# Altium schematic sheet 基本外设.SchDoc
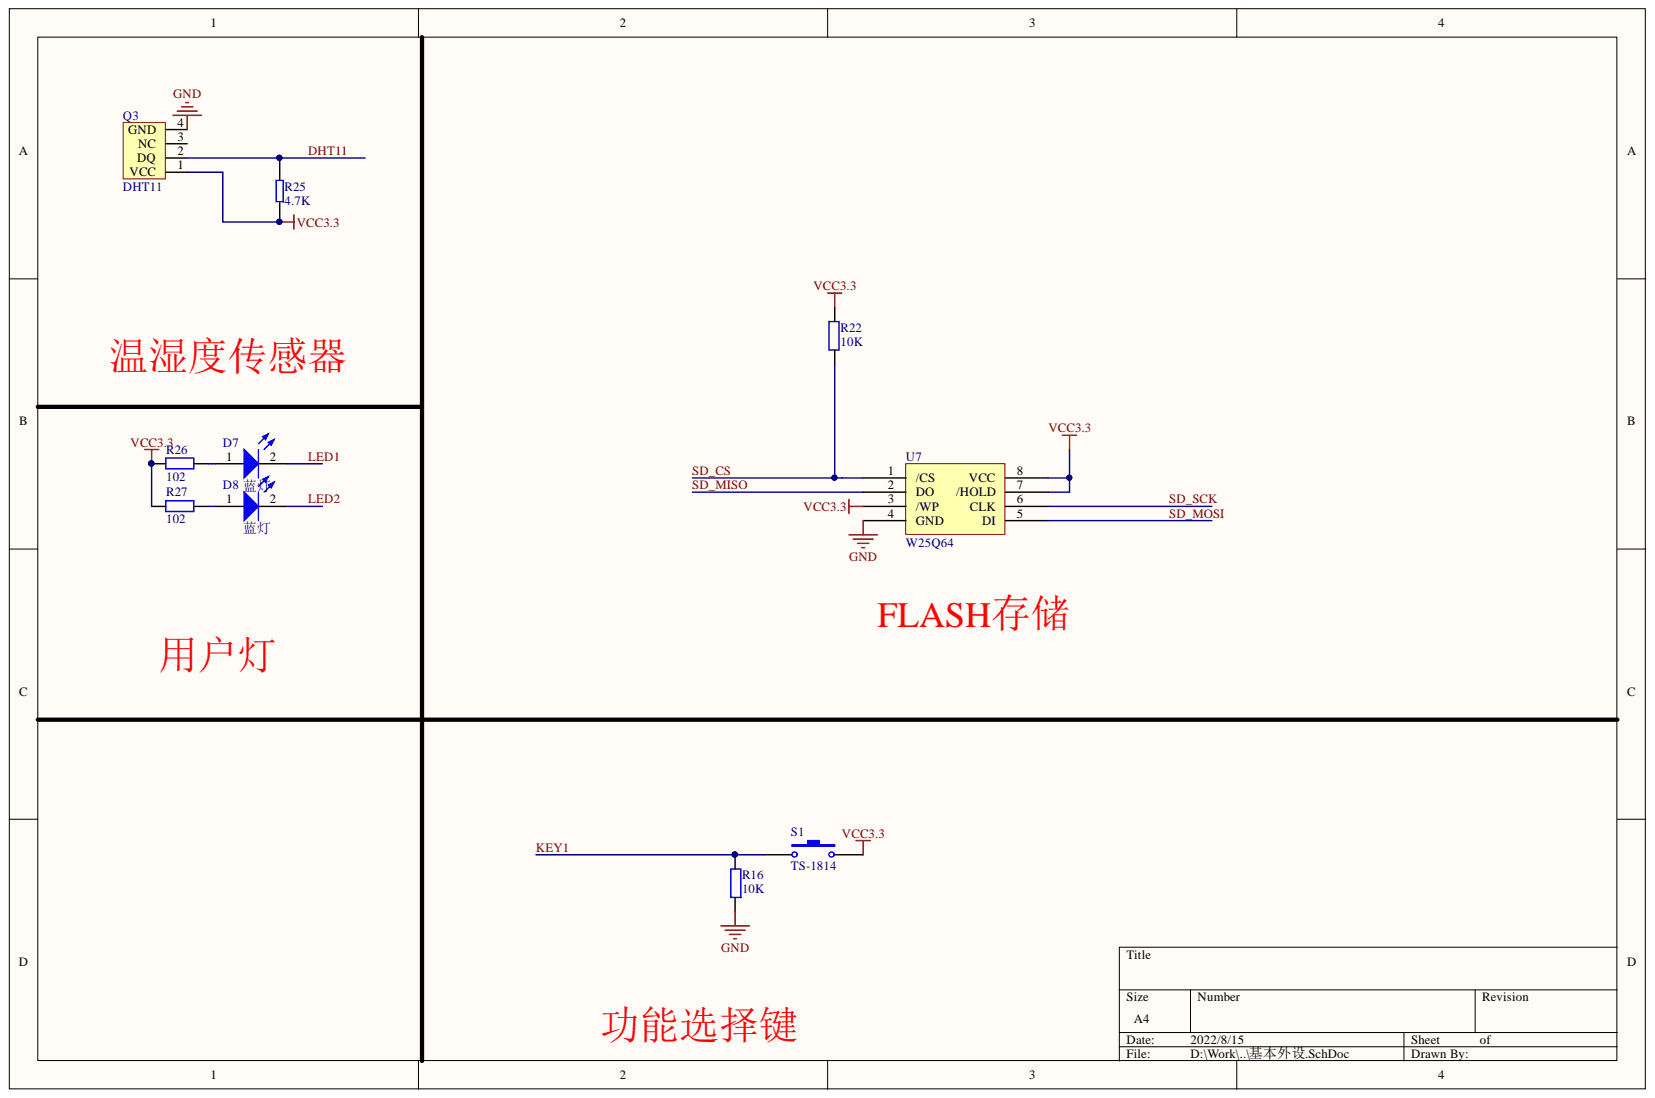
[diagram p0: 基本外设.SchDoc]

## Parts
D7  蓝灯  [LC-0805_LED]
D8  蓝灯  [LC-0805_LED]
Q3  DHT11  [DHT11]
R16  value 10K  [0603R]  (hidden comment: RES)
R22  value 10K  [0603R]  (hidden comment: RES)
R25  value 4.7K  [0603R]  (hidden comment: RES)
R26  value 102  [0603R]  (hidden comment: Res2)
R27  value 102  [0603R]  (hidden comment: Res2)
S1  TS-1814  [KEY6*6-TP]
U7  W25Q64  [SOIC-8]

## Nets
GND: Q3.4(GND) R16.1 U7.4(GND)
VCC3.3: Q3.1(VCC) R22.1 R25.1 R26.1 R27.1 S1.2 U7.3(/WP) U7.7(/HOLD) U7.8(VCC)
DHT11: Q3.2(DQ) R25.2
KEY1: R16.2 S1.1
LED1: D7.2
LED2: D8.2
SD_CS: R22.2 U7.1(/CS)
SD_MISO: U7.2(DO)
SD_MOSI: U7.5(DI)
SD_SCK: U7.6(CLK)
NetD7_1: D7.1 R26.2
NetD8_1: D8.1 R27.2

Not connected: Q3 3(NC)

## Library data of the parts
D7 D8 = LED0: Typical INFRARED GaAs LED; Component Kind: Standard; LatestRevisionDate: 08-Jul-2005; LatestRevisionNote: Mech Layer 1 removed.; PackageDescription: LED; 2 Leads; PackageReference: LED-0; Published: 8-Jun-2000; Library Name: 常用器件库.IntLib; Publisher: Altium Limited
Q3 = DHT11: 数字温湿度传感器; Component Kind: Standard; Library Name: Basic.SchLib
R26 R27 = Res2: Resistor; Component Kind: Standard; LatestRevisionDate: 17-Jul-2002; LatestRevisionNote: Re-released for DXP Platform.; PackageDescription: Axial Device, Thru-Hole; 2 Leads; 0.4 in Pin Spacing; PackageReference: AXIAL-0.4; Published: 8-Jun-2000; Library Name: 常用器件库.IntLib; Publisher: Altium Limited
S1 = SW-PB: Switch; Component Kind: Standard; LatestRevisionDate: 17-Jul-2002; LatestRevisionNote: Re-released for DXP Platform.; PackageDescription: Switch; 2 Leads; PackageReference: SPST-2; Published: 24-Mar-1999; Library Name: 常用器件库.IntLib; Publisher: Altium Limited
U7 = W25Q64: FLASH芯片; Component Kind: Standard; Library Name: 主控端最小系统.SCHLIB
R16 R22 R25 = RES: Component Kind: Standard
  R16 R22: Description: RES; Library Name: 主控端最小系统.SCHLIB
  R25: Library Name: Basic.SchLib
